# Altium schematic sheet LuisArduino.SchDoc(LuisArduino)
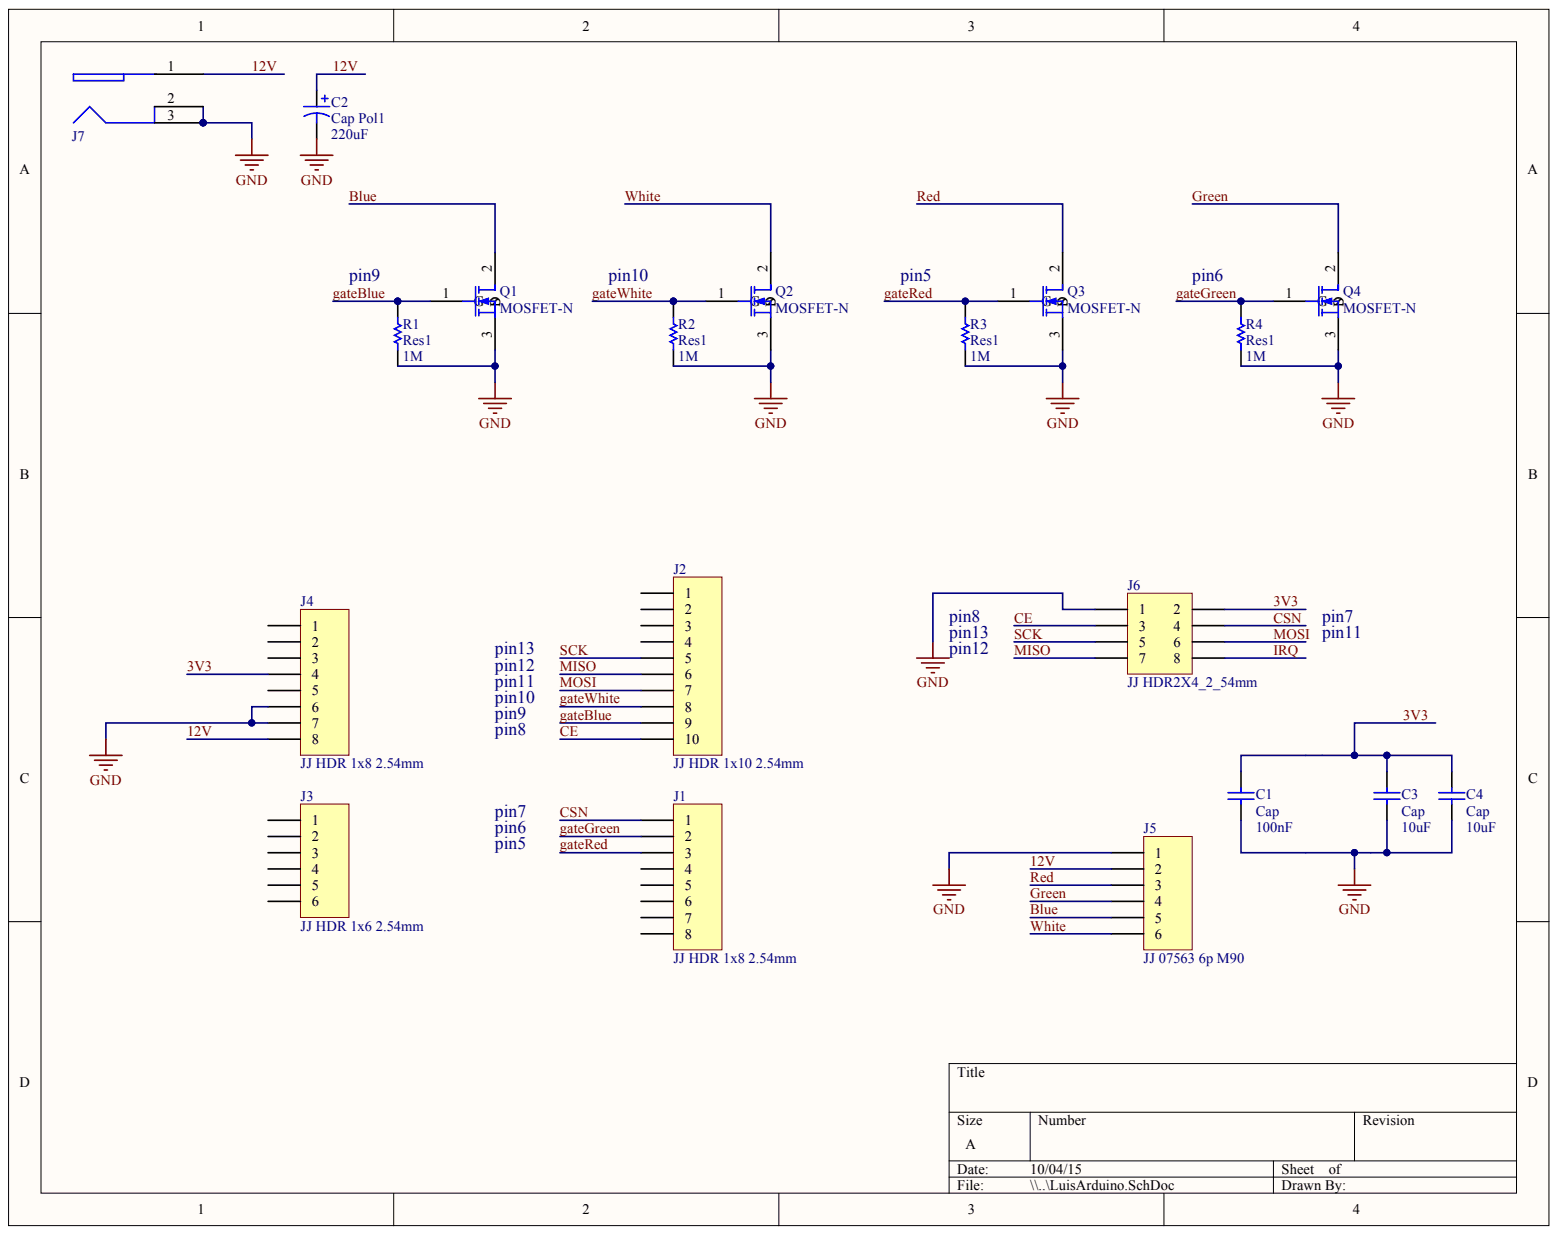
[diagram p0: LuisArduino.SchDoc]

## Parts
C1  Cap  value 100nF  [RAD-0.1]
C2  Cap Pol1  value 220uF  [RB5-10.5]
C3  Cap  value 10uF  [RAD-0.1]
C4  Cap  value 10uF  [RAD-0.1]
J1  JJ HDR 1x8 2.54mm  [HDR 1x8 - reduced pins]
J2  JJ HDR 1x10 2.54mm  [HDR 1x10 - reduced pins]
J3  JJ HDR 1x6 2.54mm  [HDR 1x6 - reduced pins]
J4  JJ HDR 1x8 2.54mm  [HDR 1x8 - reduced pins]
J5  JJ 07563 6p M90  [JJ 6PIN 5.08mm - 90 degree]
J6  JJ HDR2X4_2_54mm  [HDR 2x4 FEMALE]
J7  JJ A-DC 2.5mm Center  [JJ JACK 2.5mm PTH CUI PJ-002B]  (value not drawn)
Q1  MOSFET-N  [TO-220-AB]
Q2  MOSFET-N  [TO-220-AB]
Q3  MOSFET-N  [TO-220-AB]
Q4  MOSFET-N  [TO-220-AB]
R1  Res1  value 1M  [AXIAL-0.3]
R2  Res1  value 1M  [AXIAL-0.3]
R3  Res1  value 1M  [AXIAL-0.3]
R4  Res1  value 1M  [AXIAL-0.3]

## Nets
GND: C1.1 C2.2 C3.1 C4.1 J4.6 J4.7 J5.1 J6.1 J7.2 J7.3 Q1.3 Q2.3 Q3.3 Q4.3 R1.1 R2.1 R3.1 R4.1
3V3: C1.2 C3.2 C4.2 J4.4 J6.2
12V: C2.1 J4.8 J5.2 J7.1
BLUE: J5.5 Q1.2
CE: J2.10 J6.3
CSN: J1.1 J6.4
GATEBLUE: J2.9 Q1.1 R1.2
GATEGREEN: J1.2 Q4.1 R4.2
GATERED: J1.3 Q3.1 R3.2
GATEWHITE: J2.8 Q2.1 R2.2
GREEN: J5.4 Q4.2
IRQ: J6.8
MISO: J2.6 J6.7
MOSI: J2.7 J6.6
RED: J5.3 Q3.2
SCK: J2.5 J6.5
WHITE: J5.6 Q2.2

Not connected: J1 4 5 6 7 8; J2 1 2 3 4; J3 1 2 3 4 5 6; J4 1 2 3 5

## Library data of the parts
C1 C3 C4 = Cap: Capacitor; Component Kind: Standard; LatestRevisionDate: 17-Jul-2002; LatestRevisionNote: Re-released for DXP Platform.; Library Name: Miscellaneous Devices.IntLib; PackageDescription: Radial Cap, Thru-Hole; 2 Leads; 0.3 in Pin Spacing; PackageReference: RAD-0.3; Published: 8-Jun-2000; Signal Integrity: Cap; Simulation: CAP; Publisher: Altium Limited
C2 = Cap Pol1: Polarized Capacitor (Radial); Component Kind: Standard; LatestRevisionDate: 17-Jul-2002; LatestRevisionNote: Re-released for DXP Platform.; Library Name: Miscellaneous Devices.IntLib; PackageDescription: Polarized Capacitor (Radial); 2 Leads; PackageReference: RB7.6-15; Published: 8-Jun-2000; Signal Integrity: Cap Pol; Simulation: CAP; Publisher: Altium Limited
J5 = JJ 07563 6p M90: Terminal Block 6 pin Male Right angle; ACMEcode: JJ 07563 6p M90; Component Kind: Standard; Library Name: acmeConnect.SchLib
J6 = JJ HDR2X4_2_54mm: Header 2X4; Component Kind: Standard; LatestRevisionDate: 17-Jul-2002; LatestRevisionNote: Re-released for DXP Platform.; Library Name: acmeConnect (3).SchLib; Publisher: Altium Limited
Q1-Q4 = MOSFET-N: N-Channel MOSFET; Component Kind: Standard; LatestRevisionDate: 17-Jul-2002; LatestRevisionNote: Re-released for DXP Platform.; Library Name: Miscellaneous Devices.IntLib; PackageDescription: TO, Flat Index; 3 In-Line, Axial Leads; Body Dia. 4.6mm; Lead Dia. 0.5 mm (max); PackageReference: E3; PackageVersion: 1995/1996; Published: 8-Jun-2000; Simulation: NMOS; Publisher: Altium Limited
R1-R4 = Res1: Resistor; Component Kind: Standard; LatestRevisionDate: 17-Jul-2002; LatestRevisionNote: Re-released for DXP Platform.; Library Name: Miscellaneous Devices.IntLib; PackageDescription: Axial Device, Thru-Hole; 2 Leads; 0.3 in Pin Spacing; PackageReference: AXIAL-0.3; Published: 8-Jun-2000; Signal Integrity: Res; Simulation: RESISTOR; Publisher: Altium Limited
J1 J4 = JJ HDR 1x8 2.54mm: Component Kind: Standard; Library Name: acmeConnect.SchLib
J2 = JJ HDR 1x10 2.54mm: Component Kind: Standard; Library Name: acmeConnect (3).SchLib
J3 = JJ HDR 1x6 2.54mm: Component Kind: Standard; Library Name: acmeConnect.SchLib
J7 = JJ A-DC 2.5mm Center: Component Kind: Standard; Library Name: acmeConnect.SchLib
